# Altium schematic sheet HEADER_1.SchDoc
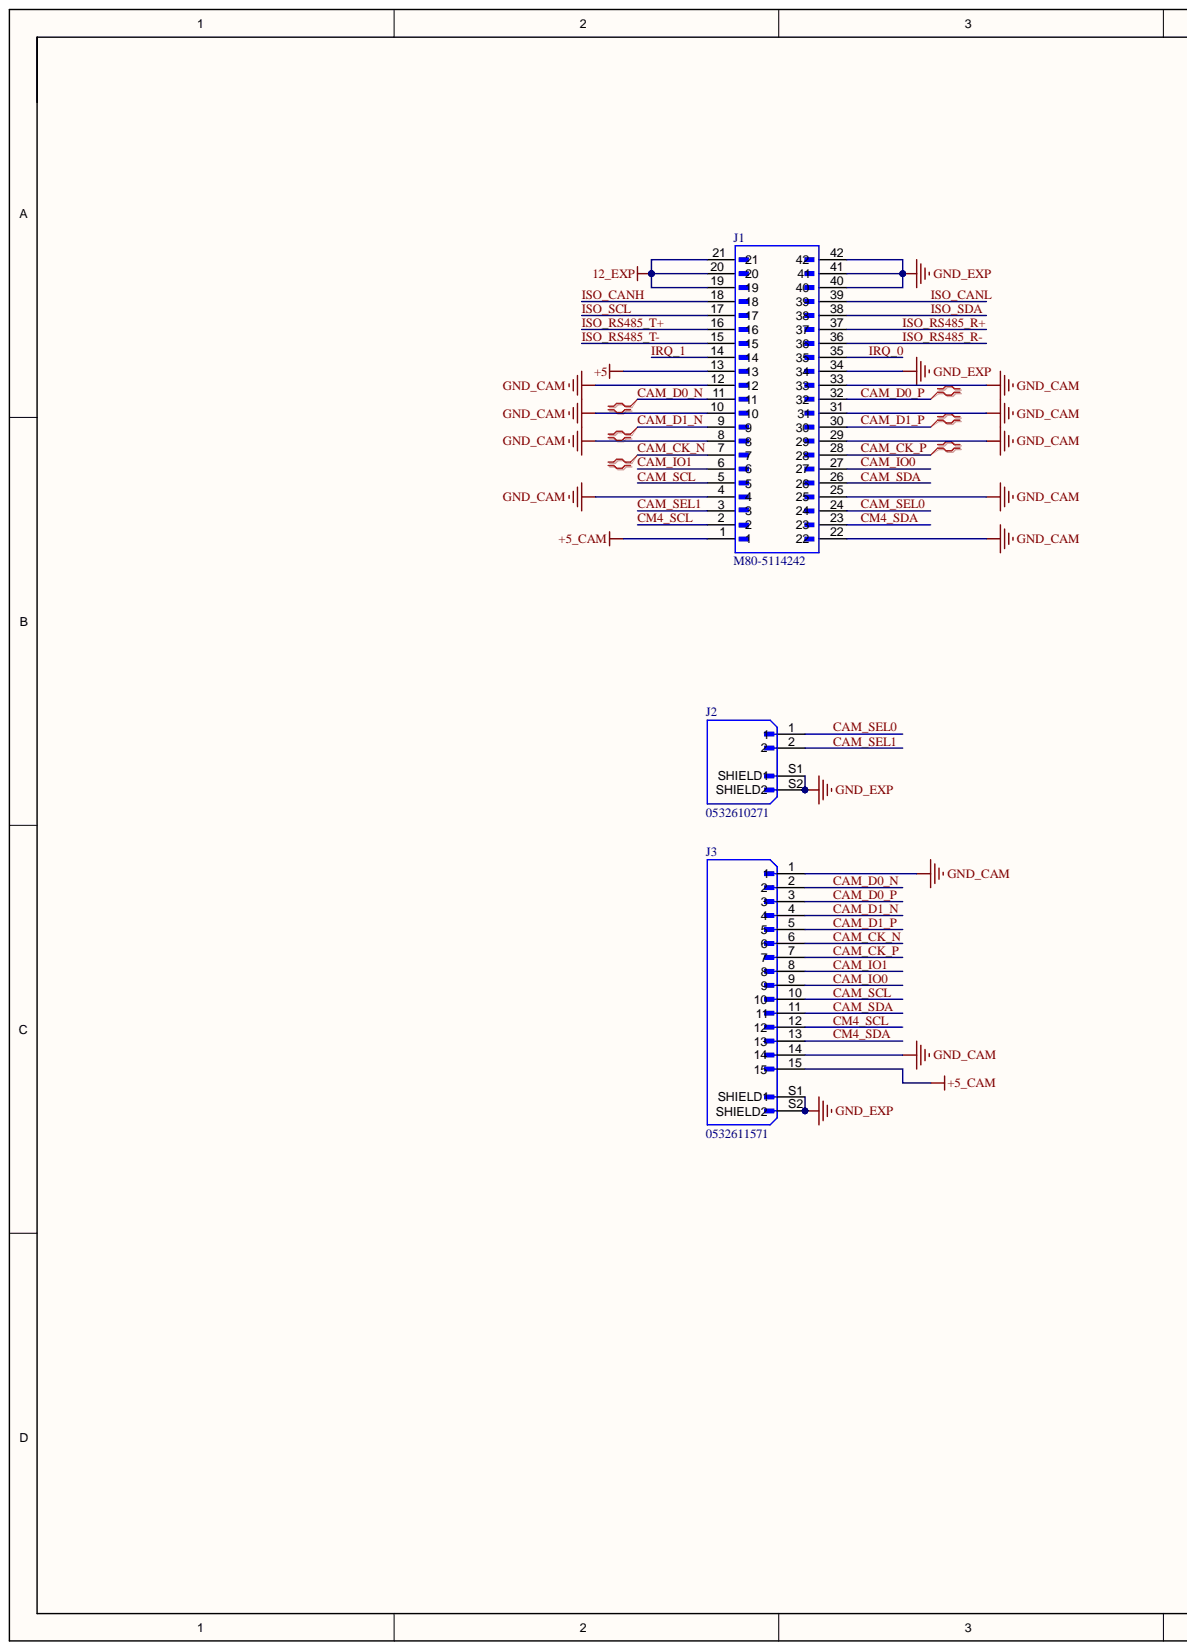
[diagram p0: HEADER_1.SchDoc, left]
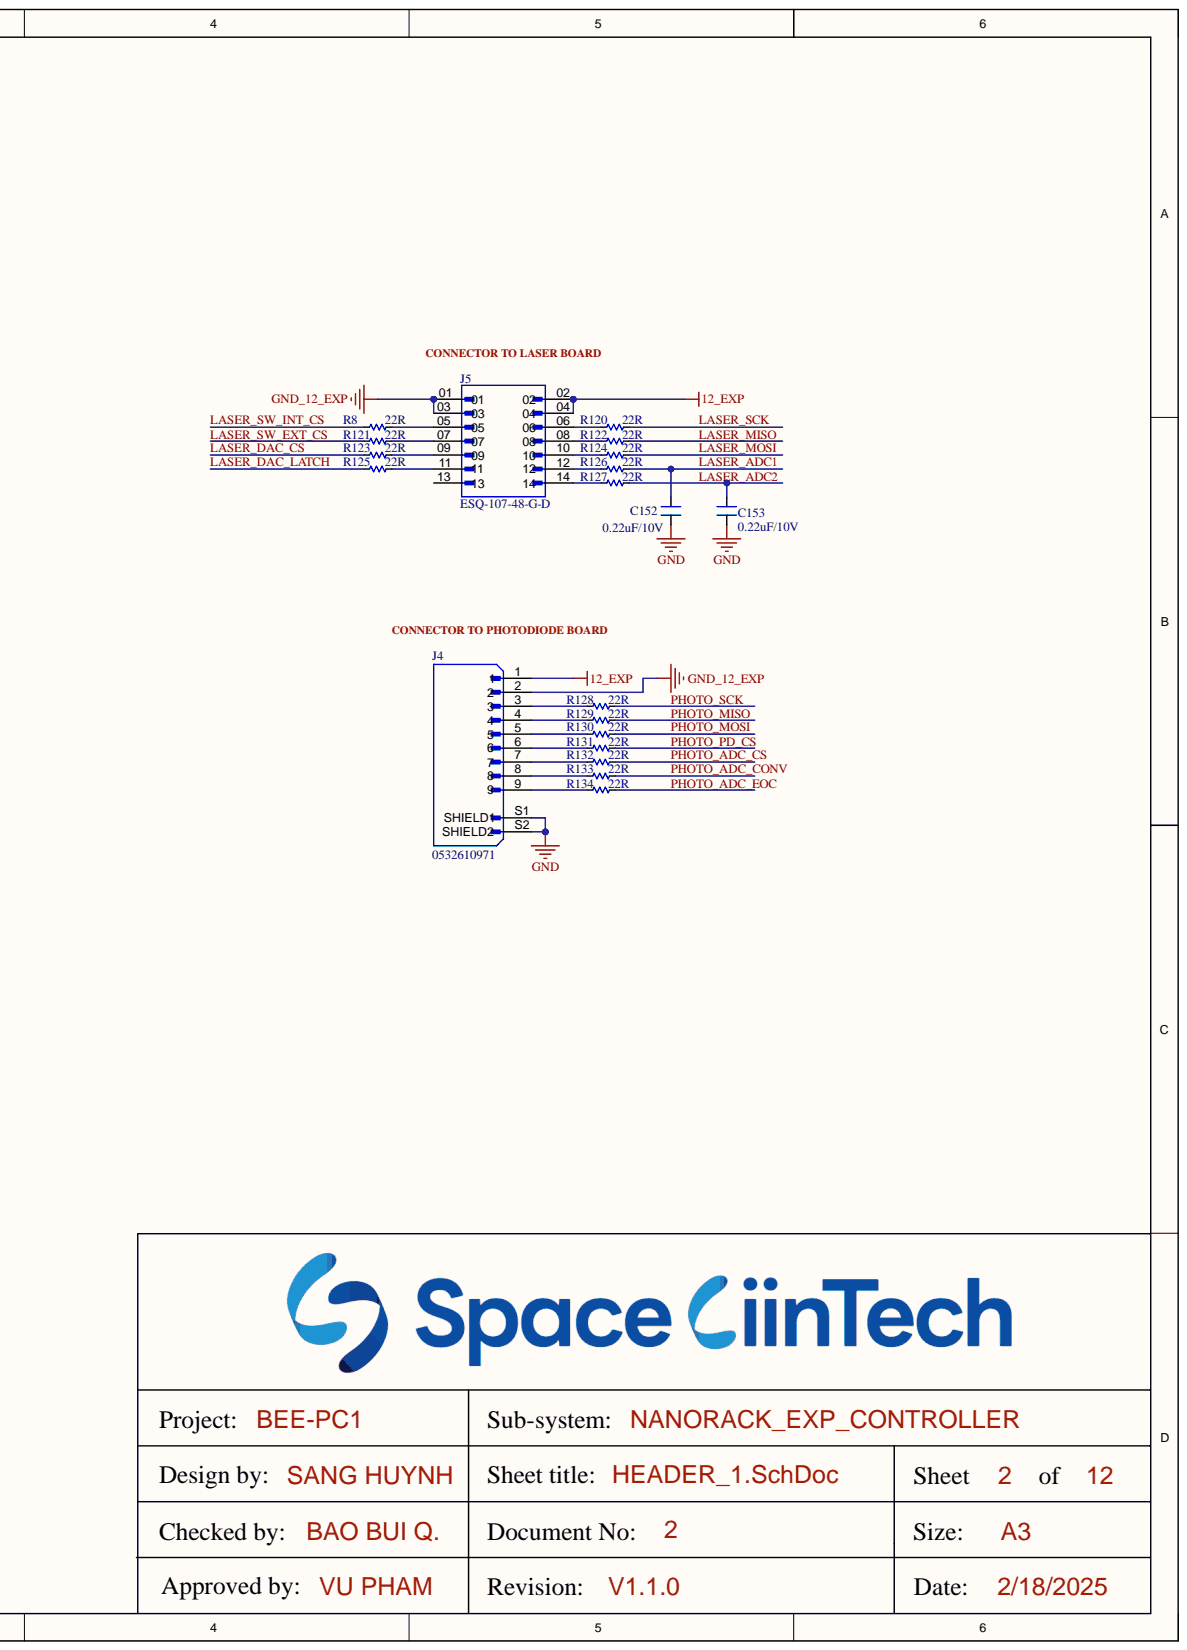
[diagram p1: HEADER_1.SchDoc, right]

## Parts
C152  0.22uF/10V  [CAP_C_1608X80]
C153  0.22uF/10V  [CAP_C_1608X80]
J1  M80-5114242  [M80-5114242]
J2  0532610271  [0532610271]
J3  0532611571  [0532611571]
J4  0532610971  [0532610971]
J5  ESQ-107-48-G-D  [ESQ-107-37-G-D]
R8  22R  [RES_C_1608X60]
R120  22R  [RES_C_1608X60]
R121  22R  [RES_C_1608X60]
R122  22R  [RES_C_1608X60]
R123  22R  [RES_C_1608X60]
R124  22R  [RES_C_1608X60]
R125  22R  [RES_C_1608X60]
R126  22R  [RES_C_1608X60]
R127  22R  [RES_C_1608X60]
R128  22R  [RES_C_1608X60]
R129  22R  [RES_C_1608X60]
R130  22R  [RES_C_1608X60]
R131  22R  [RES_C_1608X60]
R132  22R  [RES_C_1608X60]
R133  22R  [RES_C_1608X60]
R134  22R  [RES_C_1608X60]

## Nets
12_EXP: J1.19 J1.20 J1.21 J4.1 J5.02 J5.04
+5: J1.13
+5_CAM: J1.1 J3.15
GND: C152.1 C153.1 J4.S1(SHIELD1) J4.S2(SHIELD2)
GND_12_EXP: J4.2 J5.01 J5.03
GND_CAM: J1.4 J1.8 J1.10 J1.12 J1.22 J1.25 J1.29 J1.31 J1.33 J3.1 J3.14
GND_EXP: J1.34 J1.40 J1.41 J1.42 J2.S1(SHIELD1) J2.S2(SHIELD2) J3.S1(SHIELD1) J3.S2(SHIELD2)
CAM_CK_N: J1.7 J3.6
CAM_CK_P: J1.28 J3.7
CAM_D0_N: J1.11 J3.2
CAM_D0_P: J1.32 J3.3
CAM_D1_N: J1.9 J3.4
CAM_D1_P: J1.30 J3.5
CAM_IO0: J1.27 J3.9
CAM_IO1: J1.6 J3.8
CAM_SCL: J1.5 J3.10
CAM_SDA: J1.26 J3.11
CAM_SEL0: J1.24 J2.1
CAM_SEL1: J1.3 J2.2
CM4_SCL: J1.2 J3.12
CM4_SDA: J1.23 J3.13
IRQ_0: J1.35
IRQ_1: J1.14
ISO_CANH: J1.18
ISO_CANL: J1.39
ISO_RS485_R+: J1.37
ISO_RS485_R-: J1.36
ISO_RS485_T+: J1.16
ISO_RS485_T-: J1.15
ISO_SCL: J1.17
ISO_SDA: J1.38
LASER_ADC1: C152.2 R126.2
LASER_ADC2: C153.2 R127.2
LASER_DAC_CS: R123.1
LASER_DAC_LATCH: R125.1
LASER_MISO: R122.2
LASER_MOSI: R124.2
LASER_SCK: R120.2
LASER_SW_EXT_CS: R121.1
LASER_SW_INT_CS: R8.1
PHOTO_ADC_CONV: R133.2
PHOTO_ADC_CS: R132.2
PHOTO_ADC_EOC: R134.2
PHOTO_MISO: R129.2
PHOTO_MOSI: R130.2
PHOTO_PD_CS: R131.2
PHOTO_SCK: R128.2
NetJ4_3: J4.3 R128.1
NetJ4_4: J4.4 R129.1
NetJ4_5: J4.5 R130.1
NetJ4_6: J4.6 R131.1
NetJ4_7: J4.7 R132.1
NetJ4_8: J4.8 R133.1
NetJ4_9: J4.9 R134.1
NetJ5_05: J5.05 R8.2
NetJ5_06: J5.06 R120.1
NetJ5_07: J5.07 R121.2
NetJ5_08: J5.08 R122.1
NetJ5_09: J5.09 R123.2
NetJ5_10: J5.10 R124.1
NetJ5_11: J5.11 R125.2
NetJ5_12: J5.12 R126.1
NetJ5_14: J5.14 R127.1

Not connected: J5 13

## Library data of the parts
C152 C153 = Capacitors: Value: 33pF; Component Kind: Standard; Library Name: Sang_library.SchLib
J1 = CONN_TH_42: CONN HEADER VERT 42POS 2MM; Component Kind: Standard; Library Name: EXP_HW_V2.SchLib
J2 = CONN_SMD_02: CONN HEADER SMD R/A 2POS 1.25MM; Component Kind: Standard; Library Name: EXP_HW_V2.SchLib
J3 = CONN_SMD_15: Conn Shrouded Header (4 Sides) HDR 15 POS 1.25mm Solder RA Side Entry SMD PicoBladeâ„¢ T/R; MF: Molex; Availability: In Stock; Check_prices: https://www.snapeda.com/parts/532611571/Molex/view-part/?ref=eda; Component Kind: Standard; MP: 532611571; Package: None; Price: None; Purchase-URL: https://pricing.snapeda.com/search/part/532611571/?ref=eda; SnapEDA_Link: https://www.snapeda.com/parts/532611571/Molex/view-part/?ref=snap; Library Name: EXP_HW_V2.SchLib
J4 = CONN_SMD_09: CONN HEADER SMD R/A 9POS 1.25MM; Component Kind: Standard; Library Name: EXP_HW_V2.SchLib
J5 = CONN_TH_14: CONN SOCKET 14POS 0.1 GOLD PCB; Component Kind: Standard; Library Name: EXP_HW_V2.SchLib
R8 R120-R134 = Res SMD: SMD; Manufacturer: Generic Components; Class: Passive; Component Kind: Standard; Published: 08/06/2000; Library Name: Sang_library.SchLib; Publisher: Altium Hobart Technology Centre
